# Altium schematic sheet inputs.SchDoc(inputs)
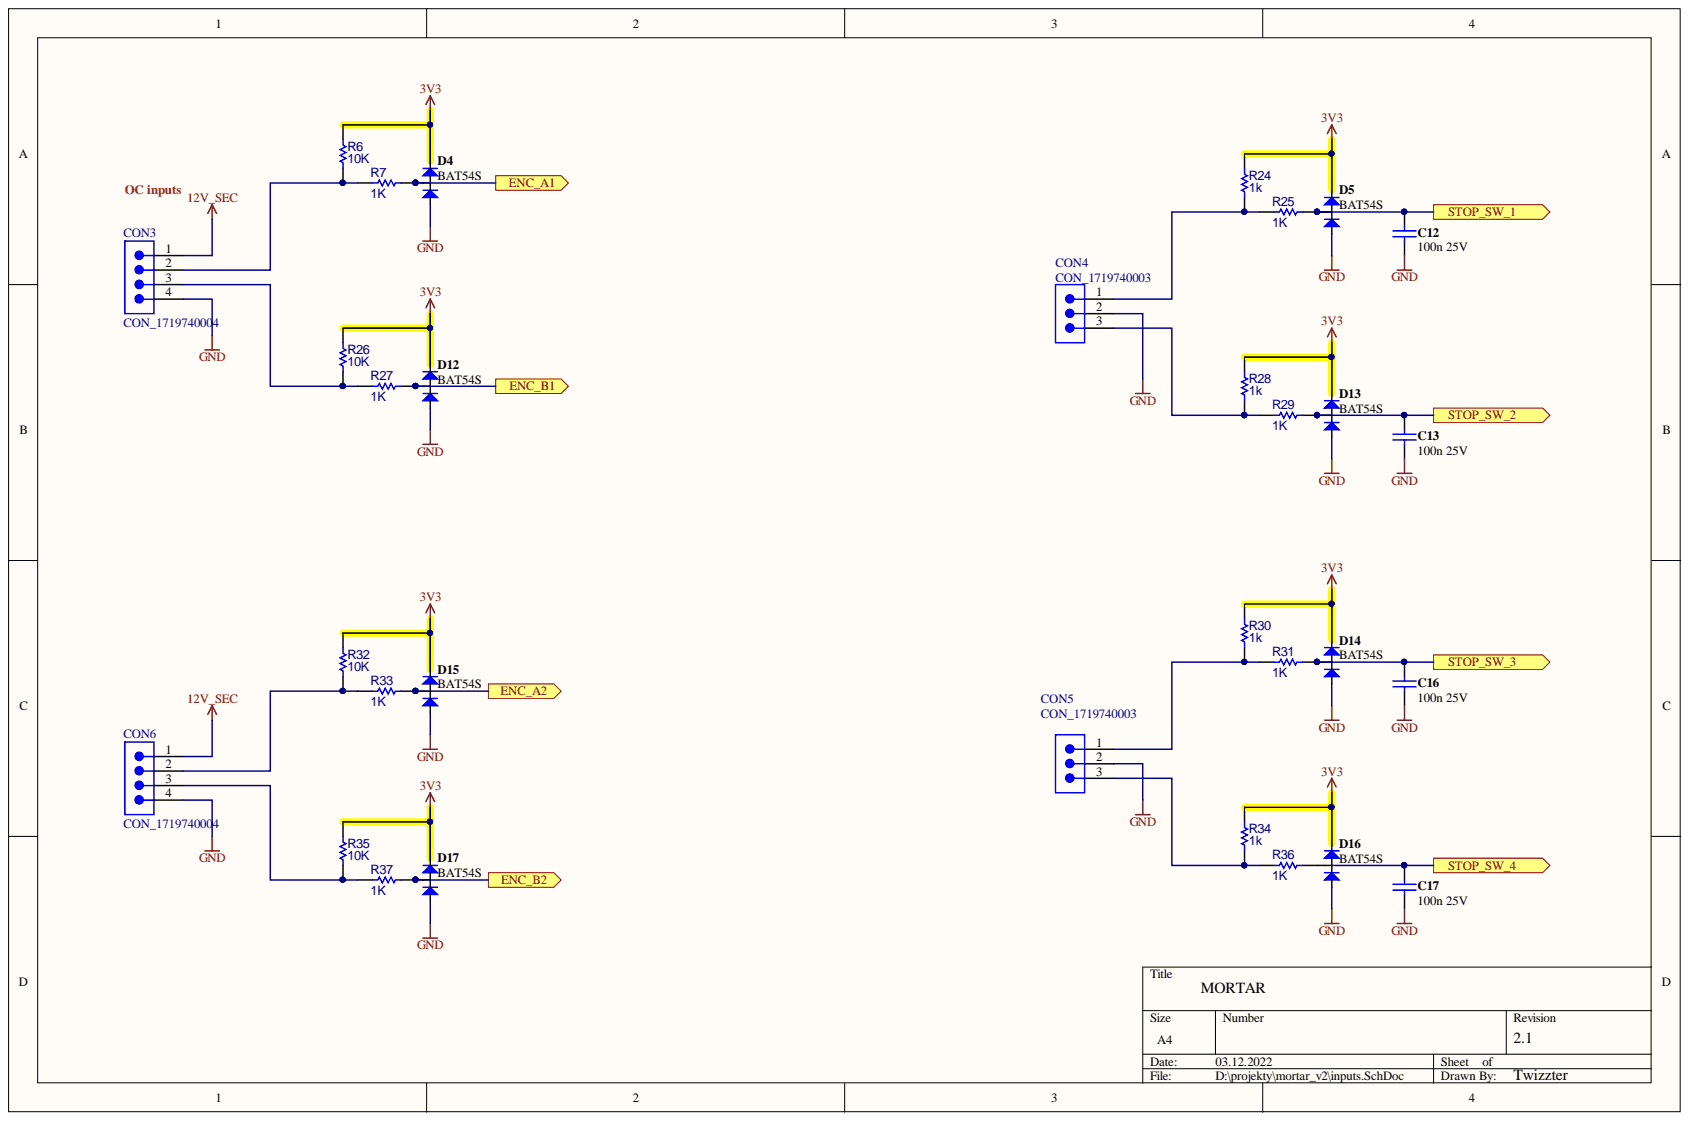
[diagram p0: inputs.SchDoc]

## Parts
C12  value 100n 25V  [C_0603]  (hidden comment: C_100n_25V_0603)
C13  value 100n 25V  [C_0603]  (hidden comment: C_100n_25V_0603)
C16  value 100n 25V  [C_0603]  (hidden comment: C_100n_25V_0603)
C17  value 100n 25V  [C_0603]  (hidden comment: C_100n_25V_0603)
CON3  CON_1719740004  [CON_1719740004]  (value not drawn)
CON4  CON_1719740003  [CON_1719740003]  (value not drawn)
CON5  CON_1719740003  [CON_1719740003]  (value not drawn)
CON6  CON_1719740004  [CON_1719740004]  (value not drawn)
D4  BAT54S  [SOT23]
D5  BAT54S  [SOT23]
D12  BAT54S  [SOT23]
D13  BAT54S  [SOT23]
D14  BAT54S  [SOT23]
D15  BAT54S  [SOT23]
D16  BAT54S  [SOT23]
D17  BAT54S  [SOT23]
R6  VALUE_TXT: 10K  [R_0603]  (hidden comment: R_10K_0603)
R7  VALUE_TXT: 1K  [R_0603]  (hidden comment: R_1K_0603)
R24  VALUE_TXT: 1k  [R_0603]  (hidden comment: R_10K_0603)
R25  VALUE_TXT: 1K  [R_0603]  (hidden comment: R_1K_0603)
R26  VALUE_TXT: 10K  [R_0603]  (hidden comment: R_10K_0603)
R27  VALUE_TXT: 1K  [R_0603]  (hidden comment: R_1K_0603)
R28  VALUE_TXT: 1k  [R_0603]  (hidden comment: R_10K_0603)
R29  VALUE_TXT: 1K  [R_0603]  (hidden comment: R_1K_0603)
R30  VALUE_TXT: 1k  [R_0603]  (hidden comment: R_10K_0603)
R31  VALUE_TXT: 1K  [R_0603]  (hidden comment: R_1K_0603)
R32  VALUE_TXT: 10K  [R_0603]  (hidden comment: R_10K_0603)
R33  VALUE_TXT: 1K  [R_0603]  (hidden comment: R_1K_0603)
R34  VALUE_TXT: 1k  [R_0603]  (hidden comment: R_10K_0603)
R35  VALUE_TXT: 10K  [R_0603]  (hidden comment: R_10K_0603)
R36  VALUE_TXT: 1K  [R_0603]  (hidden comment: R_1K_0603)
R37  VALUE_TXT: 1K  [R_0603]  (hidden comment: R_1K_0603)

## Ports
ENC_A1, ENC_A2, ENC_B1, ENC_B2, STOP_SW_1, STOP_SW_2, STOP_SW_3, STOP_SW_4

## Nets
3V3: D4.2 D5.2 D12.2 D13.2 D14.2 D15.2 D16.2 D17.2 R6.2 R24.2 R26.2 R28.2 R30.2 R32.2 R34.2 R35.2
12V_SEC: CON3.1 CON6.1
GND: C12.1 C13.1 C16.1 C17.1 CON3.4 CON4.2 CON5.2 CON6.4 D4.1 D5.1 D12.1 D13.1 D14.1 D15.1 D16.1 D17.1
ENC_A1: D4.3 R7.2 ; port ENC_A1
ENC_A2: D15.3 R33.2 ; port ENC_A2
ENC_B1: D12.3 R27.2 ; port ENC_B1
ENC_B2: D17.3 R37.2 ; port ENC_B2
STOP_SW_1: C12.2 D5.3 R25.2 ; port STOP_SW_1
STOP_SW_2: C13.2 D13.3 R29.2 ; port STOP_SW_2
STOP_SW_3: C16.2 D14.3 R31.2 ; port STOP_SW_3
STOP_SW_4: C17.2 ; port STOP_SW_4
NetCON3_2: CON3.2 R6.1 R7.1
NetCON3_3: CON3.3 R26.1 R27.1
NetCON4_1: CON4.1 R24.1 R25.1
NetCON4_3: CON4.3 R28.1 R29.1
NetCON5_1: CON5.1 R30.1 R31.1
NetCON5_3: CON5.3 R34.1 R36.1
NetCON6_2: CON6.2 R32.1 R33.1
NetCON6_3: CON6.3 R35.1 R37.1
NetD16_3: D16.3 R36.2

## Library data of the parts
C12 C13 C16 C17 = C_100n_25V_0603: 100n 25V 0603 X7R; mfg_pn: 885012206071; Library Name: SCH.SchLib; Component Kind: Standard
D4 D5 D12-D17 = BAT54S: Diode; mfg_pn: BAT54SQ-7-F; link: https://pl.mouser.com/ProductDetail/Diodes-Incorporated/BAT54SQ-7-F?qs=sGAEpiMZZMtQ8nqTKtFS%2FCxNu2bLpS4AvuFrtQozqiHJPZeL9QFxYQ%3D%3D; Library Name: SCH.SchLib; Component Kind: Standard
R6 R24 R26 R28 R30 R32 R34 R35 = R_10K_0603: SMD RES 10K-5%-1/10W; Manufacturer: YAGEO; Package: 0603; Library Name: SCH.SchLib; Component Kind: Standard
R7 R25 R27 R29 R31 R33 R36 R37 = R_1K_0603: SMD RES 1K-1%-1/10W; Manufacturer: YAGEO; Package: 0603; Library Name: SCH.SchLib; Component Kind: Standard
CON3 CON6 = CON_1719740004: Library Name: SCH.SchLib; Component Kind: Standard
CON4 CON5 = CON_1719740003: Library Name: SCH.SchLib; Component Kind: Standard
